# Altium schematic sheet audioin.SchDoc(audioin)
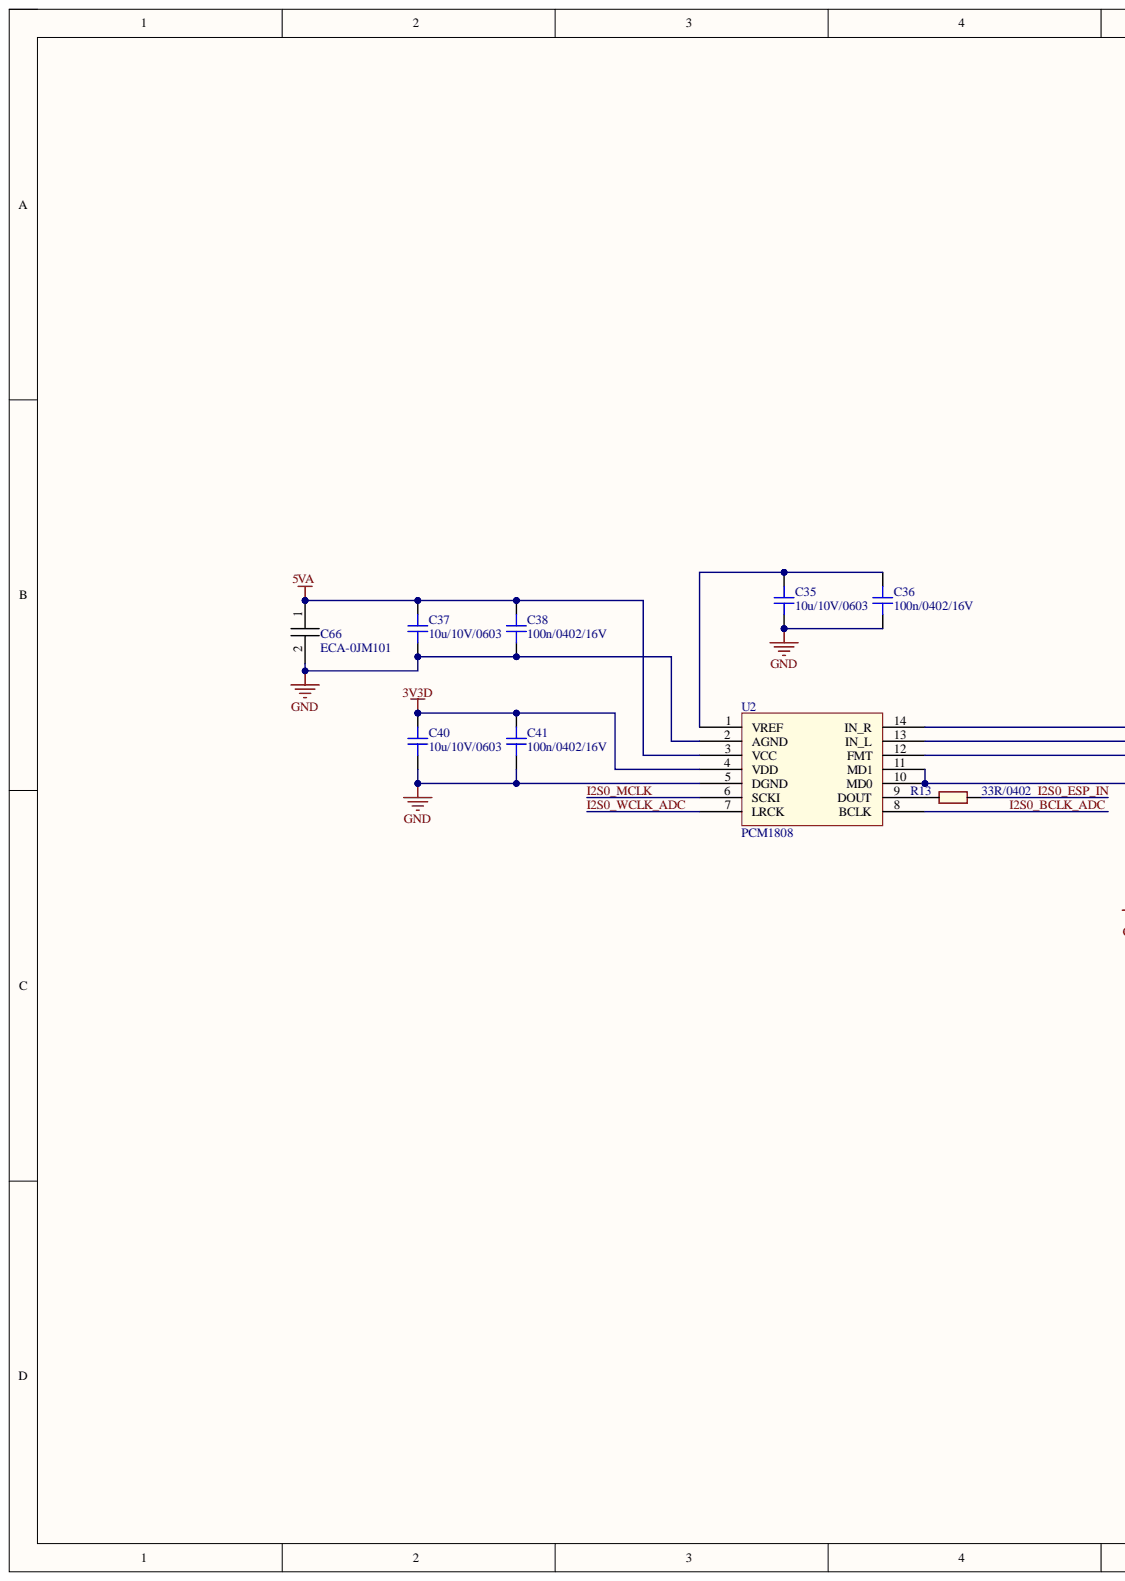
[diagram p0: audioin.SchDoc, left]
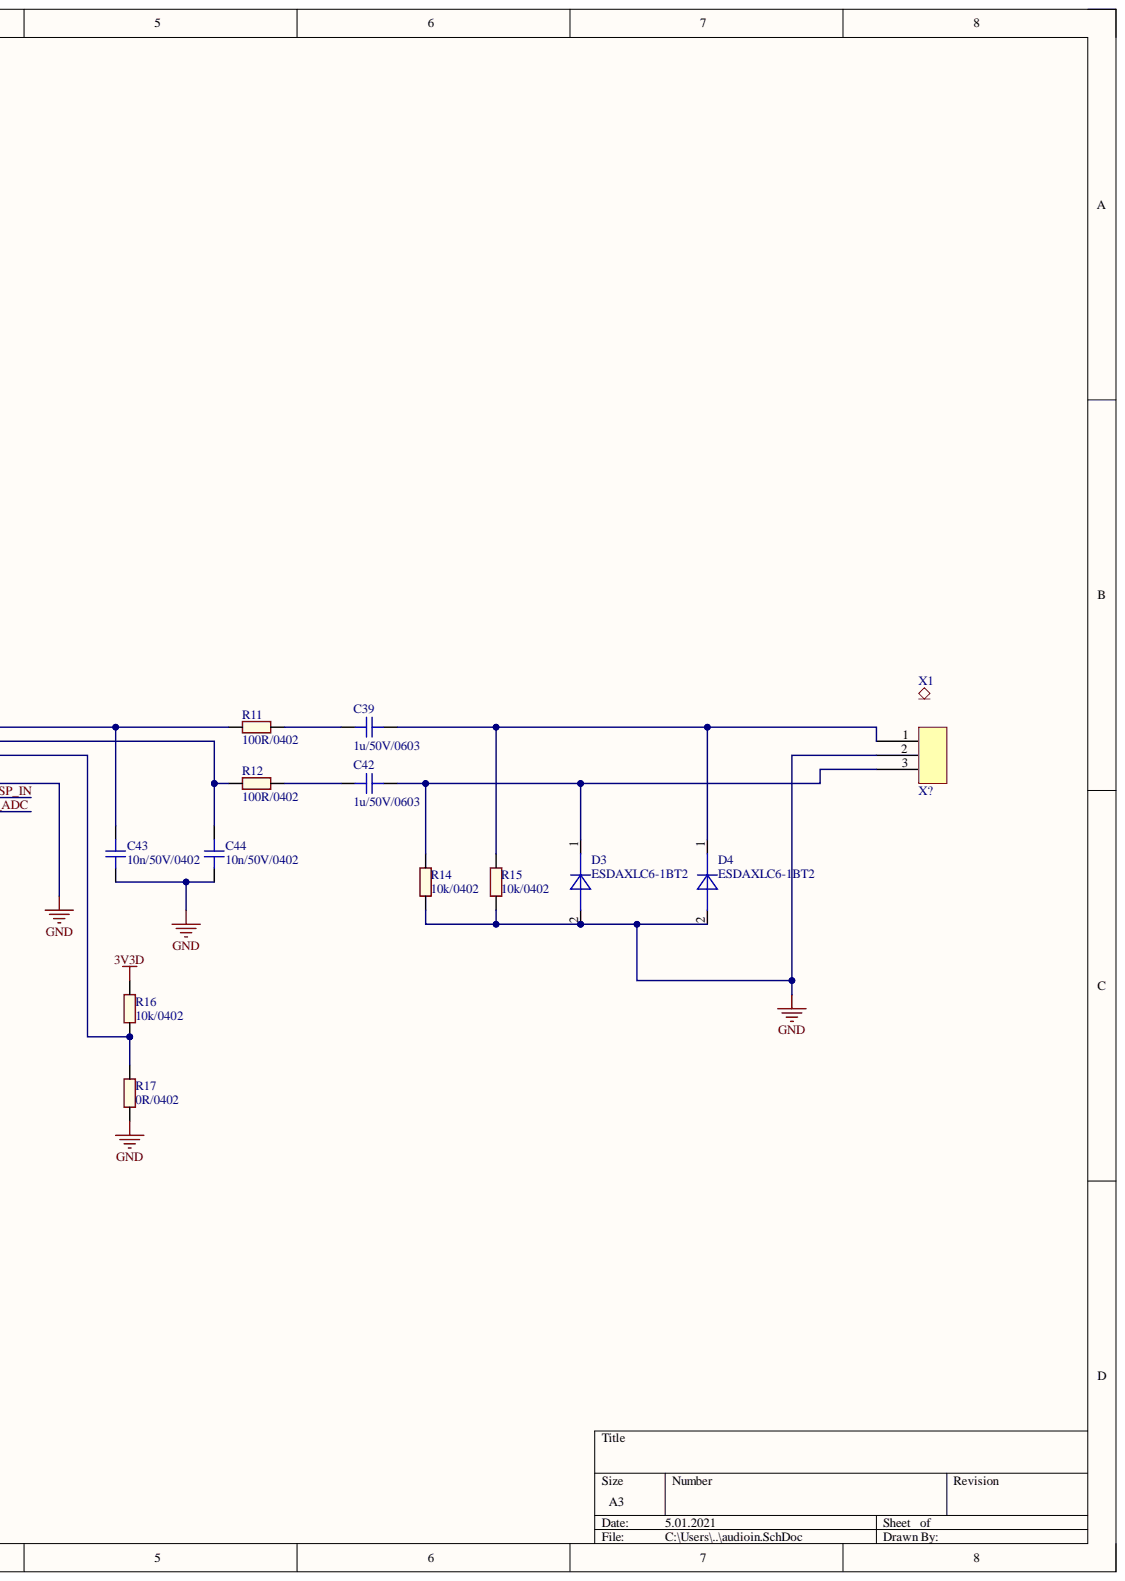
[diagram p1: audioin.SchDoc, right]

## Parts
C35  10u/10V/0603  [CAPC1608X87N]
C36  100n/0402/16V  [CAPC1005X55N-0]
C37  10u/10V/0603  [CAPC1608X87N]
C38  100n/0402/16V  [CAPC1005X55N-0]
C39  1u/50V/0603  [CAPC1608X87N]
C40  10u/10V/0603  [CAPC1608X87N]
C41  100n/0402/16V  [CAPC1005X55N-0]
C42  1u/50V/0603  [CAPC1608X87N]
C43  10n/50V/0402  [CAPC1005X55N-0]
C44  10n/50V/0402  [CAPC1005X55N-0]
C66  ECA-0JM101  [CAP_THT_5mm_rm2mm]
D3  ESDAXLC6-1BT2  [DFN98X58X50-2N]
D4  ESDAXLC6-1BT2  [DFN98X58X50-2N]
R11  100R/0402  [RESC1005X40N]
R12  100R/0402  [RESC1005X40N]
R13  33R/0402  [RESC1005X40N]
R14  10k/0402  [RESC1005X40N]
R15  10k/0402  [RESC1005X40N]
R16  10k/0402  [RESC1005X40N]
R17  0R/0402  [RESC1005X40N]
U2  PCM1808  [TSOP65P640X100-14N]
X1  X?  [JST PH 2MM 3 PIN]

## Nets
3V3D: C40.1 C41.2 R16.2 U2.4(VDD)
5VA: C37.1 C38.2 C66.1 U2.3(VCC)
GND: C35.2 C36.1 C37.2 C38.1 C40.2 C41.1 C43.2 C44.2 C66.2 D3.2 D4.2 R14.1 R15.1 R17.1 U2.2(AGND) U2.5(DGND) U2.10(MD0) U2.11(MD1) X1.2
I2S0_BCLK_ADC: U2.8(BCLK)
I2S0_ESP_IN: R13.2
I2S0_MCLK: U2.6(SCKI)
I2S0_WCLK_ADC: U2.7(LRCK)
NetC35_1: C35.1 C36.2 U2.1(VREF)
NetC39_1: C39.1 D4.1 R15.2 X1.1
NetC39_2: C39.2 R11.2
NetC42_1: C42.1 D3.1 R14.2 X1.3
NetC42_2: C42.2 R12.2
NetC43_1: C43.1 R11.1 U2.14(IN_R)
NetC44_1: C44.1 R12.1 U2.13(IN_L)
NetR13_1: R13.1 U2.9(DOUT)
NetR16_1: R16.1 R17.2 U2.12(FMT)

## Library data of the parts
C35 C37 C39 C40 C42 = 251321: CAP / CERA / 100nF / 10V / 5% / X7R (EIA) / -55°C to 125°C / 603(1608) / SMD / -; Manufacturer: Kemet; Manufacturer Order Number: C0603C104J8RAC; Alternate View: Yes; Automotive Qualified: No; Combined Parameters: TC:X7R (EIA);Tol.:-5%/+5%;Vdc:10V; ComponentStatus: Released; Database Table Name: TPartsAll; Legacy DeviceID: CAP00004163; Package Imperial: 603; Package Metric: 1608; PLMStatus: Not Recommended For New Designs; QuickPick: 100nF / 10V / 5% / X7R (EIA) / 0603; Style: Single; Symbol Type: Capacitor; Single; Standard; 2 Pins; Type: Standard; Version Number: V01; Article Number: 251321; Component Kind: Standard; ComponentLink1Description: Vendor WebSite; ComponentLink1URL: http://www.kemet.com; ComponentLink2Description: Datasheet; ComponentLink2URL: http://webnetprod.muc.infineon.com/PCBPortal_Prod/WorkFlows/AltiumComponent/StandardDocumentation?Versionid=251322; Legacy PartNumber: CAP00004163; Library Name: PCBLibraryData.SVNDbLib; Multiple Parts: No; Package Description: 0603; PackageReference: 0603; Part Type: Capacitor; ROHS Compliant: Y; Second Source allowed: Yes; Supplier 1: Farnell; Supplier 2: DigiKey; Supplier 3: Mouser; Supplier Part Number 2: C0603C104J8RACTU-ND; Technology: Ceramic; Temperature max: 125; Temperature min: -55; Temperature Range: Military; Tolerance Neg: 5%; Tolerance Pos: 5%; Value: 100nF; Voltage DC (max): 10V
C36 C38 C41 C43 C44 = 263539: CAP / CERA / 10nF / 6.3V / 10% / X5R (EIA) / -55°C to 85°C / 0402(1005) / SMD / -; Manufacturer: MuRata; Manufacturer Order Number: GRM155R60J103KA01; Alternate View: Yes; Automotive Qualified: No; Combined Parameters: TC:X5R (EIA);Tol.:-10%/+10%;Vdc:6.3V; ComponentStatus: Released; Database Table Name: TPartsAll; Legacy DeviceID: CAP01001500; Package Imperial: 0402; Package Metric: 1005; PLMStatus: Not Recommended For New Designs; QuickPick: 10nF / 6.3V / 10% / X5R (EIA) / CAPC1005X55N-0; Style: Single; Symbol Type: Capacitor; Single; Standard; 2 Pins; Type: Standard; Version Number: V01; Application: Standard; Article Number: 263539; Component Kind: Standard; ComponentLink1Description: Vendor WebSite; ComponentLink1URL: http://www.murata.com/; ComponentLink2Description: Datasheet; ComponentLink2URL: http://webnetprod.muc.infineon.com/PCBPortal_Prod/WorkFlows/AltiumComponent/StandardDocumentation?Versionid=263540; Legacy PartNumber: CAP01001500; Library Name: PCBLibraryData.SVNDbLib; Multiple Parts: No; Package Description: Capacitor,non-polarized,Chip;1.00mm L X 0.50mm W X 0.55mm H; PackageReference: CAPC1005X55N-0; Part Type: Capacitor; ROHS Compliant: Y; Second Source allowed: Yes; Supplier 1: Farnell; Supplier 2: DigiKey; Supplier 3: Mouser; Technology: Ceramic; Temperature max: 85; Temperature min: -55; Temperature Range: Military; Tolerance Neg: 10%; Tolerance Pos: 10%; Value: 10nF; Voltage DC (max): 6.3V
C66 = CAP_THT_5mm: Component Kind: Standard; Library Name: MarkusLib.IntLib
D3 D4 = 612400: High-voltage switching diode, Vr 200V; Manufacturer: Nexperia; Manufacturer Order Number: BAS21LLYL; Automotive Qualified: AEC Q101; Combined Parameters: Vf:1.25V;If:330mA;Ur:200V;Ir:100nA;Pmax:610mW; ComponentStatus: Released; Database Table Name: TPartsAll; PLMStatus: Active; QuickPick: Standard Diode / SOD882; Second Source allowed: Yes; Style: Single; Symbol Type: Standard Diode Symbol, 2 Leads, 1 Cathode, 2 Anode; Type: Standard Diode; Value: BAS21LLYL; Version Number: V01; Voltage DC (max): na; Application: Switching; Article Number: 612400; Component Kind: Standard; ComponentLink1Description: Vendor WebSite; ComponentLink1URL: http://www.nexperia.com; ComponentLink2Description: Datasheet; ComponentLink2URL: http://webnetprod.muc.infineon.com/PCBPortal_Prod/WorkFlows/AltiumComponent/StandardDocumentation?Versionid=612401; Library Name: PCBLibraryData.SVNDbLib; Package Description: Diode, SMD, 2 pin, 0.985 mm L X 0.585 mm W X 0.50 mm H body; PackageReference: SOD882; Part Type: Diode; ROHS Compliant: Y; Supplier 1: Farnell; Supplier 2: DigiKey; Supplier 3: Mouser; Supplier Part Number 1: 3020932; Supplier Part Number 2: 1727-7664-1-ND; Supplier Part Number 3: 771-BAS21LLYL; Temperature max: 150; Temperature min: -55; Temperature Range: Military
R11-R17 = 342167: RES / STD / 10k / 63mW / 1% / 100ppm/K / -55°C to 155°C / 0402 / SMD / -; Manufacturer: Vishay; Manufacturer Order Number: CRCW040210K0FK; Multiple Parts: No; Alternate View: Yes; Automotive Qualified: AEC Q200; Combined Parameters: TCR:100ppm/K;Tol.:-1%/+1%;Vdc:50V;Idc:-;P:63mW; ComponentStatus: Released; Database Table Name: TPartsAll; Legacy DeviceID: RES01800457; PLMStatus: Active; QuickPick: 10k / 1% / 0.063 / 0402; Style: Single; Symbol Type: Resistor; Single; Standard; 2 Pins; Version Number: V01; Voltage DC (max): 50V; Article Number: 342167; Component Kind: Standard; ComponentLink1Description: Vendor WebSite; ComponentLink1URL: http://www.vishay.com; ComponentLink2Description: Datasheet; ComponentLink2URL: http://webnetprod.muc.infineon.com/PCBPortal_Prod/WorkFlows/AltiumComponent/StandardDocumentation?Versionid=342168; Legacy PartNumber: RES01800457; Library Name: PCBLibraryData.SVNDbLib; PackageReference: 0402; Part Type: Resistor; ROHS Compliant: Y; Second Source allowed: Yes; Supplier 1: Farnell; Supplier 2: DigiKey; Supplier 3: Mouser; Technology: Thick Film; Temperature max: 155; Temperature min: -55; Temperature Range: Military; Tolerance Neg: 1%; Tolerance Pos: 1%; Type: Standard; Value: 10k
X1 = JST PH 2mm 3pin: Component Kind: Standard; Library Name: MarkusLib.IntLib
U2 = PCM1808: Component Kind: Standard; Library Name: MarkusLib.IntLib
